# Altium schematic sheet Power Stage.SchDoc("Power Stage")
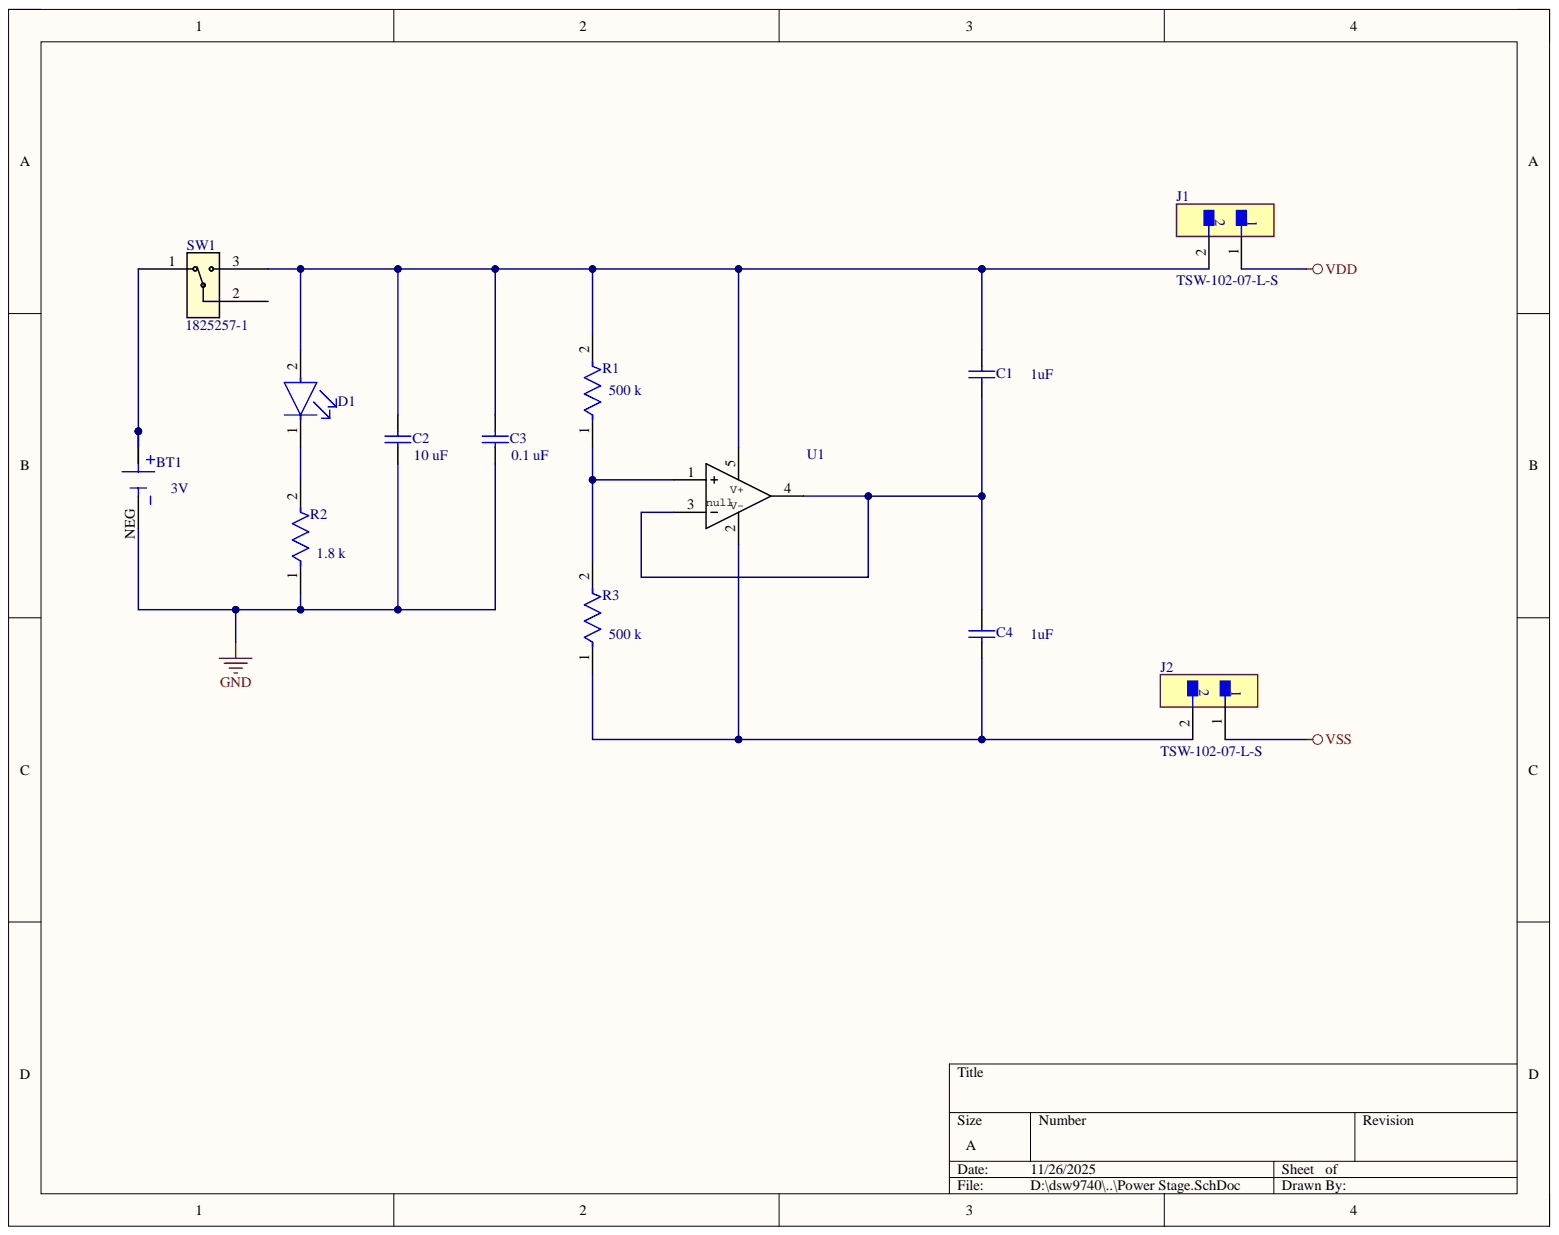
[diagram p0: Power Stage.SchDoc]

## Parts
BT1  BK-868  [BAT_BK-868]  (value not drawn)
C1  C0805C225K9PACTU  [CAPC2013X145X50ML20T25]  (value not drawn)
C2  C0805C225K9PACTU  [CAPC2013X145X50ML20T25]  (value not drawn)
C3  C0805C225K9PACTU  [CAPC2013X145X50ML20T25]  (value not drawn)
C4  C0805C225K9PACTU  [CAPC2013X145X50ML20T25]  (value not drawn)
D1  APHCM2012SYCK-F01  [FP-APHCM2012SYCK-F01-MFG]  (value not drawn)
J1  TSW-102-07-L-S  [FP-TSW-102-07-L-S-MFG]
J2  TSW-102-07-L-S  [FP-TSW-102-07-L-S-MFG]
R1  CRCW080516R0FKEA  [FP-CRCW0805-e3-MFG]  (value not drawn)
R2  CRCW080516R0FKEA  [FP-CRCW0805-e3-MFG]  (value not drawn)
R3  CRCW080516R0FKEA  [FP-CRCW0805-e3-MFG]  (value not drawn)
SW1  1825257-1  [SPDT_1825257-1_TEC]
U1  TLV9041IDCKR  [DCK0005A-IPC_A]  (value not drawn)

## Nets
GND: BT1.NEG C2.1 C3.1 R2.1
VDD: J1.1
VSS: J2.1
NetBT1_S1+: BT1.S1+ BT1.S2+ SW1.1
NetC1_1: C1.1 C4.2 U1.3 U1.4
NetC1_2: C1.2 C2.2 C3.2 D1.2 J1.2 R1.2 SW1.3 U1.5(V+)
NetC4_1: C4.1 J2.2 R3.1 U1.2(V-)
NetD1_1: D1.1 R2.2
NetR1_1: R1.1 R3.2 U1.1

Not connected: SW1 2

## Library data of the parts
BT1 = BK-868: BATTERY RETAINER COIN 16MM SMD; MF: MPD; Availability: Unavailable; Component Kind: Standard; DigiKey-Purchase-URL: https://snapeda.com/shop?store=DigiKey&id=4219637; Library Name: BK-868.SchLib; MP: BK-868; Package: None; Price: None; SnapEDA_Link: https://www.snapeda.com/parts/BK-868/Memory%20Protection%20Devices/view-part/4219637/?ref=snap
C1-C4 = CMP-1036-04819-2: Manufacturer: Kemet; Component Kind: Standard; Library Name: Altium Content Vault; Part Number: C0805C225K9PACTU
D1 = CMP-44529-000064-1: LED YELLOW CLEAR CHIP SMD; Dominant Wavelength: 590nm; Forward Current: 20mA; Forward Voltage: 2V; Illumination Color: Green; Luminous Intensity: 150mcd; Number of LEDs: 1; Viewing Angle: 110°; Case Code (Imperial): 0805; Case Code (Metric): 2012; Case/Package: 0805; Color: Yellow; Component Kind: Standard; Height: 0.4mm; Lead Free: Lead Free; Length: 2mm; Lens Color: Clear; Lens Style: Clear; Library Name: Altium Content Vault; Max Operating Temperature: 85°C; Min Operating Temperature: -40°C; Mount: Surface Mount; Number of Pins: 2; Package Quantity: 2000; Packaging: Tape and Reel; RoHS Compliant: Yes; Series: APHCM; Test Current: 20mA; Wavelength: 590nm; Width: 1.25mm
J1 J2 = CMP-02766-001428-1: CONN HEADER VERT 2POS 2.54MM; Connector Type: Male Pin; Current Rating: 7.6A; Gender: Male; Max Voltage Rating (AC): 550V; Number of Contacts: 2; Color: Black; Component Kind: Standard; Contact Material: Bronze; Contact Mating Length: 0.23inch; Contact Plating: Gold; Contact Type: Male Pin; Depth: 2.54mm; Housing Color: Black; Housing Material: Polyester; Lead Free: Lead Free; Length: 5.08mm; Library Name: Altium Content Vault; Max Current Rating: 7.6A; Max Operating Temperature: 125°C; Min Operating Temperature: -55°C; Mount: Through Hole; Number of Positions: 2; Number of Rows: 1; Orientation: Straight; Package Quantity: 1; Packaging: Bulk; Pitch: 2.54mm; Radiation Hardening: No; REACH SVHC: No SVHC; RoHS Compliant: Yes; Series: TSW; Termination: Solder; Voltage Rating: 550V; Width: 2.54mm
R1-R3 = CMP-02407-002795-1: RES Thick Film, 16Ω, 1%, 0.125W, 100ppm/°C, 0805; Max Power Dissipation: 125mW; Resistance: 16R; Voltage Rating: 150V; Case Code (Imperial): 0805; Case Code (Metric): 2012; Case/Package: 0805; Component Kind: Standard; Composition: Thick Film; Contact Plating: Tin; Depth: 1.25mm; Features: Automotive AEC-Q200; Height: 0.02inch; Lead Free: Lead Free; Length: 2mm; Library Name: Altium Content Vault; Max Operating Temperature: 155°C; Military Standard: Not; Min Operating Temperature: -55°C; Number of Pins: 2; Number of Terminations: 2; Package Quantity: 10; Packaging: Tape and Reel; Power Rating: 125mW; Radiation Hardening: No; Temperature Coefficient: 100ppm/°C; Termination: SMD/SMT; Tolerance: 1%; Voltage Rating (DC): 150V; Weight: 0.000194oz; Width: 0.049inch
SW1 = 1825257-1: MSS120004=SPDT SL SW VERT AG; Manufacturer_Name: TE Connectivity; Component Kind: Standard; Library Name: 1825257-1.SchLib; Manufacturer_Part_Number: 1825257-1; RefDes: RefDes; Type: Type; Copyright: Copyright (C) 2025 Ultra Librarian. All rights reserved.
U1 = TLV9041IDCKR: Single, 5.5-V, 350-kHz, ultra-low 1.2-V, low-quiescent current (10-Î¼A) op amp 5-SC70 -40 to 125; Manufacturer_Name: Texas Instruments; Component Kind: Standard; Library Name: TLV9041IDCKR.SchLib; Manufacturer_Part_Number: TLV9041IDCKR; RefDes: RefDes; TYPE: TYPE; Copyright: Copyright (C) 2025 Ultra Librarian. All rights reserved.
